# Altium schematic sheet Gateway_GNSS_Board.SchDoc
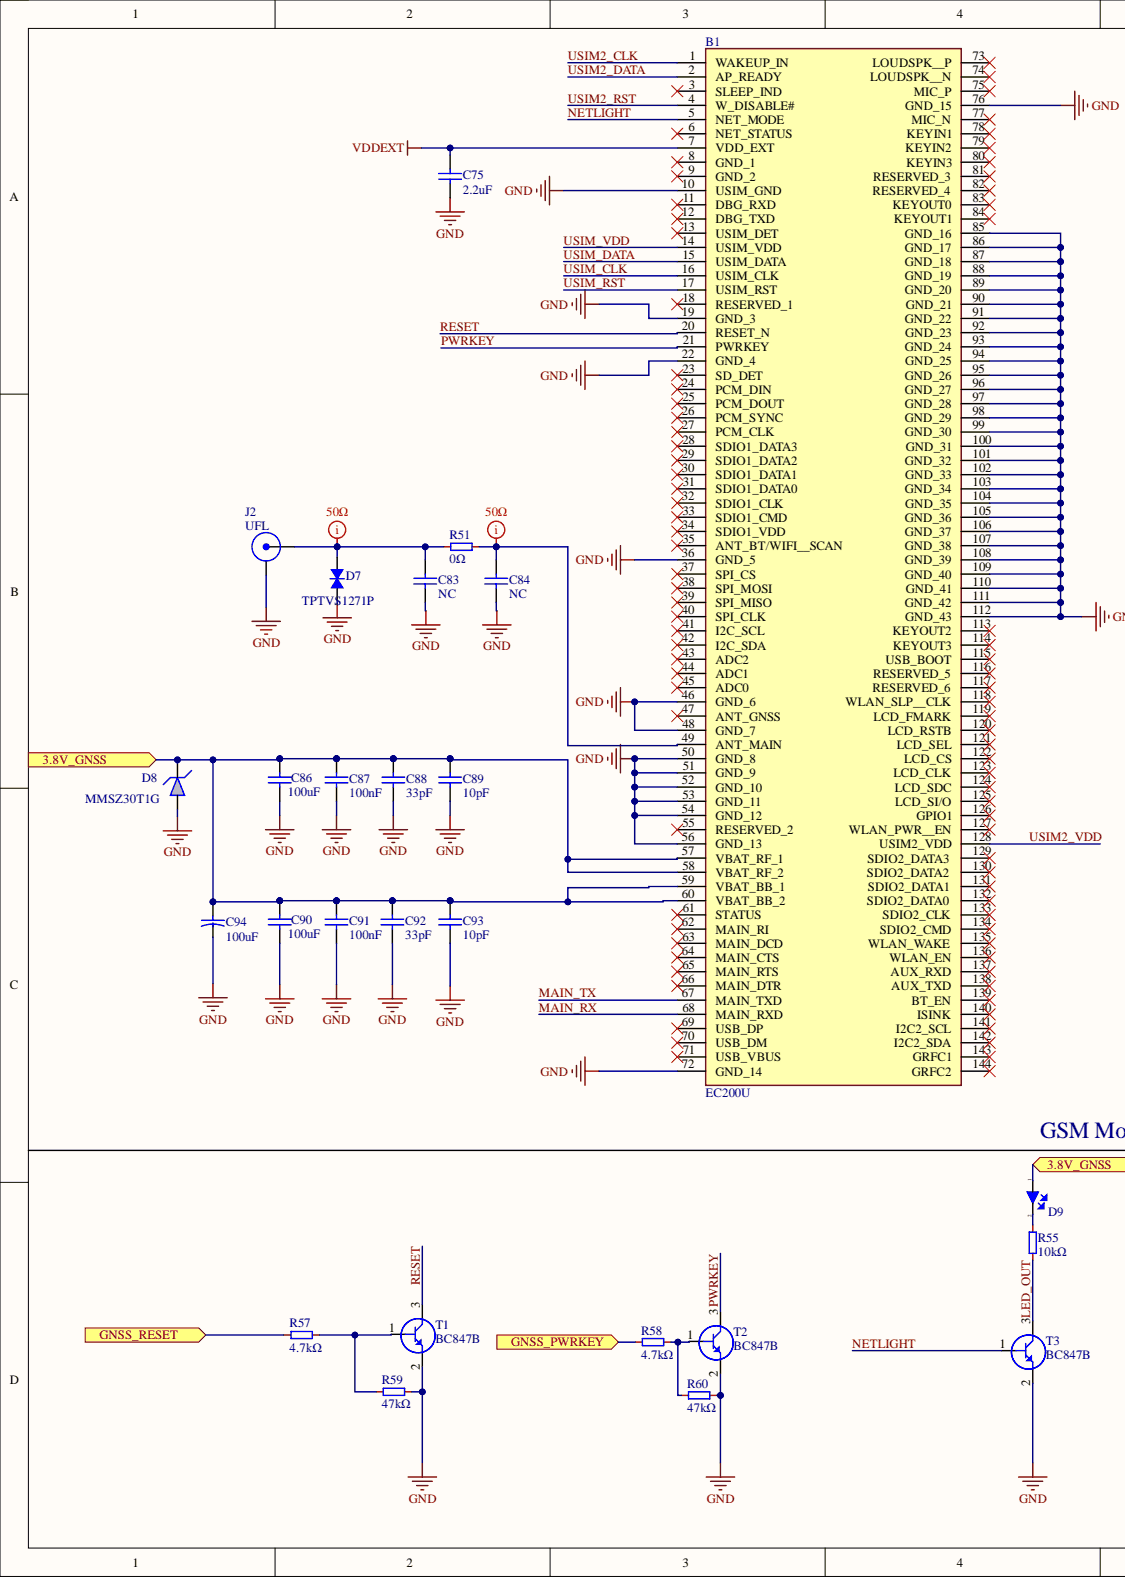
[diagram p0: Gateway_GNSS_Board.SchDoc, left]
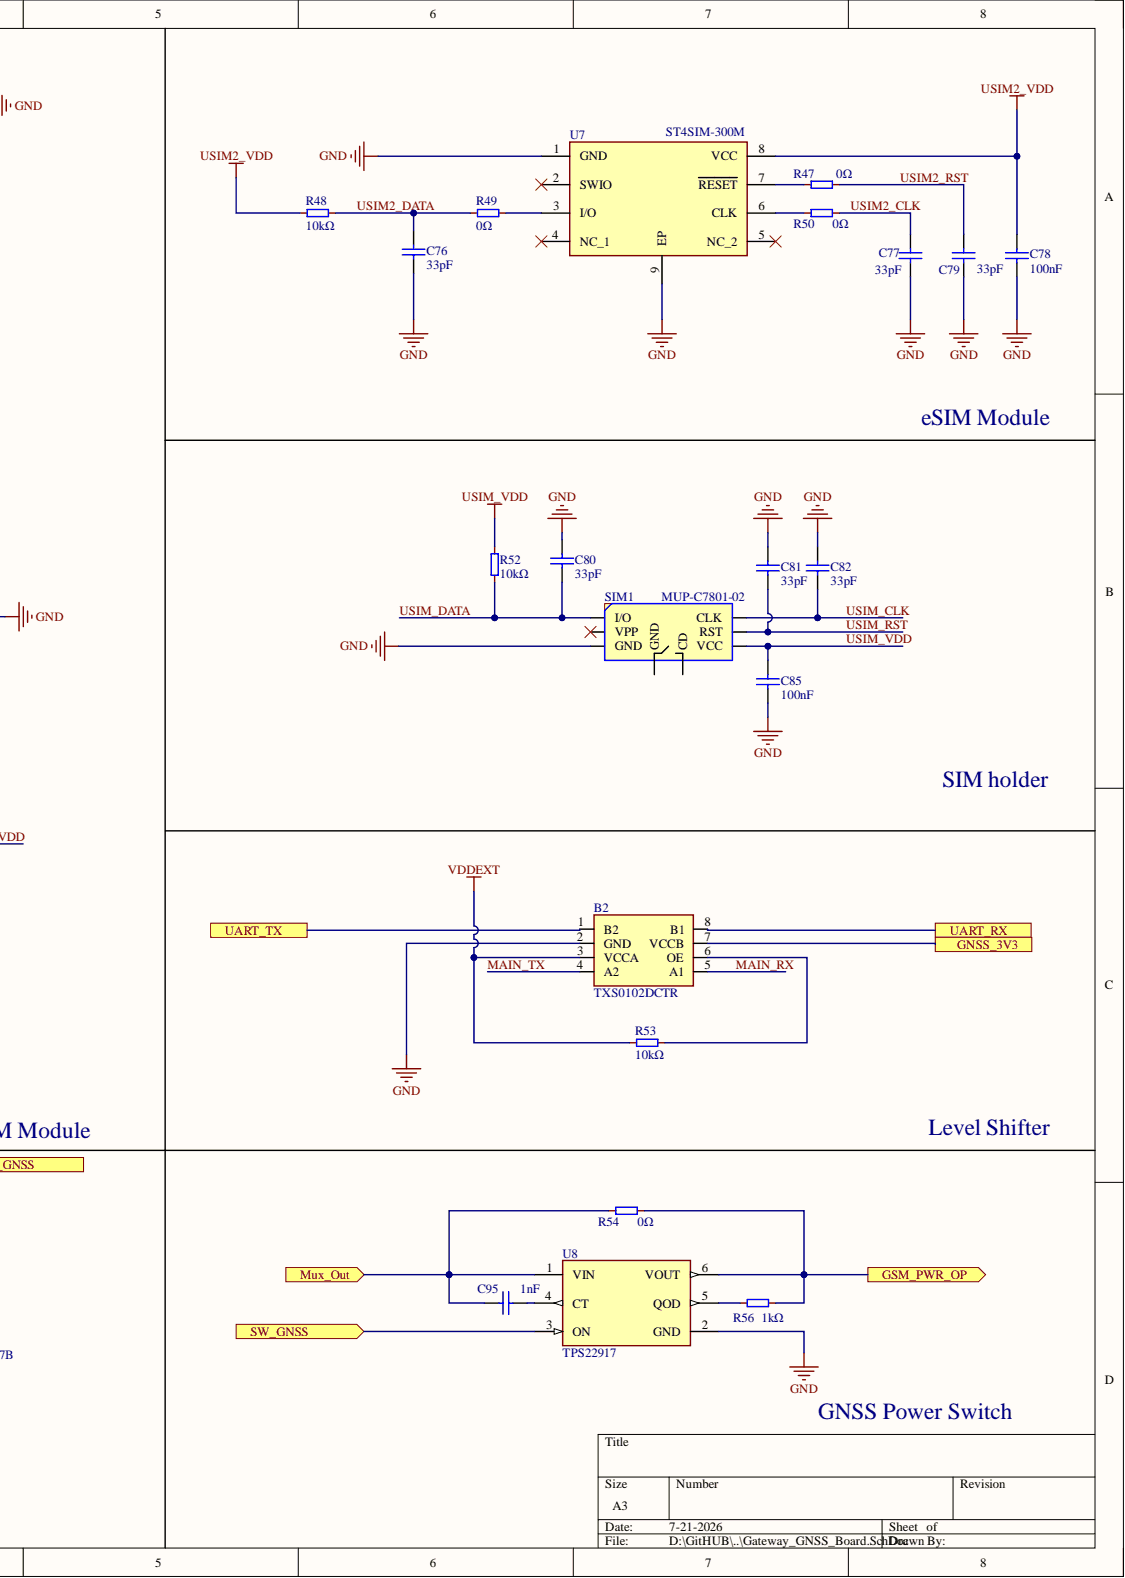
[diagram p1: Gateway_GNSS_Board.SchDoc, right]

## Parts
B1  EC200U  [EC200U]
B2  TXS0102DCTR  [SOP65P400X130-8N]
C75  2.2uF  [CAP0402]
C76  33pF  [CAP0402]
C77  33pF  [CAP0402]
C78  100nF  [CAP0402]
C79  33pF  [CAP0402]
C80  33pF  [CAP0402]
C81  33pF  [CAP0402]
C82  33pF  [CAP0402]
C83  NC  [CAP0402]
C84  NC  [CAP0402]
C85  100nF  [CAP0402]
C86  100uF  [CAP1206]
C87  100nF  [CAP0402]
C88  33pF  [CAP0402]
C89  10pF  [CAP0402]
C90  100uF  [CAP1206]
C91  100nF  [CAP0402]
C92  33pF  [CAP0402]
C93  10pF  [CAP0402]
C94  100uF  [8*10.2-CAP]
C95  1nF  [CAPC1005X06N]
D7  TPTVS1271P  [TVS Diode]
D8  MMSZ30T1G  [SOD 80]
D9  COLOR  [L_0805]  (value not drawn)
J2  UFL  [UFL]
R47  0Ω  [RESC0402]
R48  10kΩ  [RESC0402]
R49  0Ω  [RESC0402]
R50  0Ω  [RESC0402]
R51  0Ω  [RESC0402]
R52  10kΩ  [RESC0402]
R53  10kΩ  [RESC0402]
R54  0Ω  [RESC0402]
R55  10kΩ  [RESC0402]
R56  1kΩ  [RESC0402]
R57  4.7kΩ  [RESC0402]
R58  4.7kΩ  [RESC0402]
R59  47kΩ  [RESC0402]
R60  47kΩ  [RESC0402]
SIM1  MUP-C7801-02  [MUP-C7801-2]
T1  BC847B  [SOT23]
T2  BC847B  [SOT23]
T3  BC847B  [SOT23]
U7  ST4SIM-300M  [VFDFPN8 MFF2]
U8  TPS22917  [SOT23-6]

## Ports
3.8V_GNSS, GNSS_3V3, GNSS_PWRKEY, GNSS_RESET, GSM_PWR_OP, Mux_Out, SW_GNSS, UART_RX, UART_TX

## Nets
GND: B1.10(USIM_GND) B1.19(GND_3) B1.22(GND_4) B1.36(GND_5) B1.46(GND_6) B1.48(GND_7) B1.50(GND_8) B1.51(GND_9) B1.52(GND_10) B1.53(GND_11) B1.54(GND_12) B1.56(GND_13) B1.72(GND_14) B1.76(GND_15) B1.85(GND_16) B1.86(GND_17) B1.87(GND_18) B1.88(GND_19) B1.89(GND_20) B1.90(GND_21) B1.91(GND_22) B1.92(GND_23) B1.93(GND_24) B1.94(GND_25) B1.95(GND_26) B1.96(GND_27) B1.97(GND_28) B1.98(GND_29) B1.99(GND_30) B1.100(GND_31) B1.101(GND_32) B1.102(GND_33) B1.103(GND_34) B1.104(GND_35) B1.105(GND_36) B1.106(GND_37) B1.107(GND_38) B1.108(GND_39) B1.109(GND_40) B1.110(GND_41) B1.111(GND_42) B1.112(GND_43) B2.2(GND) C75.2 C76.2 C77.2 C78.2 C79.2 C80.1 C81.1 C82.1 C83.1 C84.1 C85.2 C86.1 C87.2 C88.2 C89.2 C90.1 C91.2 C92.2 C93.2 C94.2 D7.2 D8.2 J2.2 R59.1 R60.1 SIM1.GND T1.2 T2.2 T3.2 U7.1(GND) U7.9(EP) U8.2(GND)
VDDEXT: B1.7(VDD_EXT) B2.3(VCCA) C75.1 R53.2
3.8V_GNSS: B1.57(VBAT_RF_1) B1.58(VBAT_RF_2) B1.59(VBAT_BB_1) B1.60(VBAT_BB_2) C86.2 C87.1 C88.1 C89.1 C90.2 C91.1 C92.1 C93.1 C94.1 D8.1 D9.1 ; port 3.8V_GNSS
GNSS_3V3: B2.7(VCCB) ; port GNSS_3V3
GNSS_PWRKEY: R58.2 ; port GNSS_PWRKEY
GNSS_RESET: R57.2 ; port GNSS_RESET
GSM_PWR_OP: R54.2 R56.2 U8.6(VOUT) ; port GSM_PWR_OP
LED_OUT: R55.2 T3.3
MAIN_RX: B1.68(MAIN_RXD) B2.5(A1)
MAIN_TX: B1.67(MAIN_TXD) B2.4(A2)
Mux_Out: C95.2 R54.1 U8.1(VIN) ; port Mux_Out
NETLIGHT: B1.5(NET_MODE) T3.1
PWRKEY: B1.21(PWRKEY) T2.3
RESET: B1.20(RESET_N) T1.3
SW_GNSS: U8.3(ON) ; port SW_GNSS
UART_RX: B2.8(B1) ; port UART_RX
UART_TX: B2.1 ; port UART_TX
USIM2_CLK: B1.1(WAKEUP_IN) C77.1 R50.2
USIM2_DATA: B1.2(AP_READY) C76.1 R48.1 R49.1
USIM2_RST: B1.4(W_DISABLE#) C79.1 R47.2
USIM2_VDD: B1.128(USIM2_VDD) C78.1 R48.2 U7.8(VCC)
USIM_CLK: B1.16(USIM_CLK) C82.2 SIM1.CLK
USIM_DATA: B1.15(USIM_DATA) C80.2 R52.1 SIM1.I/O
USIM_RST: B1.17(USIM_RST) C81.2 SIM1.RST
USIM_VDD: B1.14(USIM_VDD) C85.1 R52.2 SIM1.VCC
NetB1_49: B1.49(ANT_MAIN) C84.2 R51.2
NetB2_6: B2.6(OE) R53.1
NetC83_2: C83.2 D7.1 J2.1 R51.1
NetC95_1: C95.1 U8.4(CT)
NetD9_2: D9.2 R55.1
NetR47_1: R47.1 U7.7(RESET)
NetR49_2: R49.2 U7.3(I/O)
NetR50_1: R50.1 U7.6(CLK)
NetR56_1: R56.1 U8.5(QOD)
NetR57_1: R57.1 R59.2 T1.1
NetR58_1: R58.1 R60.2 T2.1

Not connected: B1 3(SLEEP_IND) 6(NET_STATUS) 8(GND_1) 9(GND_2) 11(DBG_RXD) 12(DBG_TXD) 13(USIM_DET) 18(RESERVED_1) 23(SD_DET) 24(PCM_DIN) 25(PCM_DOUT) 26(PCM_SYNC) 27(PCM_CLK) 28(SDIO1_DATA3) 29(SDIO1_DATA2) 30(SDIO1_DATA1) 31(SDIO1_DATA0) 32(SDIO1_CLK) 33(SDIO1_CMD) 34(SDIO1_VDD) 35(ANT_BT/WIFI__SCAN) 37(SPI_CS) 38(SPI_MOSI) 39(SPI_MISO) 40(SPI_CLK) 41(I2C_SCL) 42(I2C_SDA) 43(ADC2) 44(ADC1) 45(ADC0) 47(ANT_GNSS) 55(RESERVED_2) 61(STATUS) 62(MAIN_RI) 63(MAIN_DCD) 64(MAIN_CTS) 65(MAIN_RTS) 66(MAIN_DTR) 69(USB_DP) 70(USB_DM) 71(USB_VBUS) 73(LOUDSPK__P) 74(LOUDSPK__N) 75(MIC_P) 77(MIC_N) 78(KEYIN1) 79(KEYIN2) 80(KEYIN3) 81(RESERVED_3) 82(RESERVED_4) 83(KEYOUT0) 84(KEYOUT1) 113(KEYOUT2) 114(KEYOUT3) 115(USB_BOOT) 116(RESERVED_5) 117(RESERVED_6) 118(WLAN_SLP__CLK) 119(LCD_FMARK) 120(LCD_RSTB) 121(LCD_SEL) 122(LCD_CS) 123(LCD_CLK) 124(LCD_SDC) 125(LCD_SI/O) 126(GPIO1) 127(WLAN_PWR__EN) 129(SDIO2_DATA3) 130(SDIO2_DATA2) 131(SDIO2_DATA1) 132(SDIO2_DATA0) 133(SDIO2_CLK) 134(SDIO2_CMD) 135(WLAN_WAKE) 136(WLAN_EN) 137(AUX_RXD) 138(AUX_TXD) 139(BT_EN) 140(ISINK) 141(I2C2_SCL) 142(I2C2_SDA) 143(GRFC1) 144(GRFC2); SIM1 CD VPP; U7 2(SWIO) 4(NC_1) 5(NC_2)

## Library data of the parts
B1 = EC200U: LTE standard module Bluetooth wireless communication 4G cat1 module all-network; Manufacturer_Name: Quectel; Geometry.Height: 2.6mm; Component Kind: Standard; Datasheet Link: https://drive.google.com/file/d/1eptLu98ynaKmYC8Qn_q-jmLHFTbR9duW/view?usp=sharing; Manufacturer_Part_Number: EC200U; Library Name: macnman_3.0.SchLib
B2 = TXS0102DCTR: Translation - Voltage Levels 2B Bidir Vltg-Level Translator; Manufacturer: Texas Instruments; Geometry.Height: 1.3mm; Component Kind: Standard; Datasheet Link: https://www.ti.com/lit/ds/symlink/txs0102.pdf?ts=1619522054575&ref_url=https%253A%252F%252Fwww.ti.com%252Fstore%252Fti%252Fen%252Fp%252Fproduct%252F%253Fp%253DTXS0102DCTT; Manufacturer_Part_Number: TXS0102DCTR; Mouser Part Number: TXS0102DCTR; Mouser Price/Stock: https://www.mouser.co.uk/ProductDetail/Texas-Instruments/TXS0102DCTTE4?qs=IUbkBnfnSQLBbpjVS5PRXQ%3D%3D; Library Name: macnman_3.0.SchLib
C75 = Capa_0402: Ceramic Capacitor - SMD X7R 0402 2.2uF 10V 10%; Manufacturer: Murata; Manufacturer_Part_Number: GRM155Z71A225KE44D; Component Kind: Standard; Supplier 1: Robu; Supplier Part Number 1: GRM155Z71A225KE44D; Library Name: macnman_2.0.SchLib
C76 C77 C79-C82 C88 C92 = Capa_0402: 33pF 50V Capacitor 5% Tolerance CC0402JRNPO9BN330- 0402 Package; Manufacturer: YAGEO; Component Kind: Standard; Manufacturer_Part_Number: CC0402JRNPO9BN330; Library Name: macnman_2.0.SchLib
C78 C85 C87 C91 = Capa_0402: Ceramic Capacitor C0G, NP0 0402 100nF 35V; Manufacturer: MURATA; Manufacturer_Part_Number: GRM155R61A104KA01J; Component Kind: Standard; Library Name: macnman_2.0.SchLib
C83 C84 = Capa_0402: Ceramic Capacitor C0G, NC NP0 0402; Manufacturer: YAGEO; Manufacturer_Part_Number: CC0402KRX7R9BB105; Component Kind: Net Tie; Library Name: macnman_2.0.SchLib
C86 C90 = CAP_0805: Ceramic Capacitor - SMD 100uF 10V 20% 1206 X5R; Manufacturer: MURATA; Manufacturer_Part_Number: GRM31CR61A107MEA8K; Component Kind: Standard; Supplier: Robu; Supplier_Part_Number: GRM31CR61A107MEA8K; Library Name: macnman_2.0.SchLib
C89 C93 = Capa_0402: Ceramic Capacitor C0G, NP0 0402 10pF 35V; Manufacturer: YAGEO; Manufacturer_Part_Number: CC0402JRNPO9BN100; Component Kind: Standard; Library Name: macnman_2.0.SchLib
C94 = CAP_EL: Aluminum Electrolytic - Radial 100µF 10V 20%; Manufacturer: Lelon; Manufacturer_Part_Number: VES101M1ATR; Component Kind: Standard; Supplier: Robu; Supplier Part Number 1: VES101M1ATR; Library Name: macnman_2.0.SchLib
C95 = MCD-00198: Ceramic Capacitor - SMD 1nF 25V C0G 5% 0402; Manufacturer: Murata; Manufacturer_Part_Number: GRT1555C1E102JA02J; Component Kind: Standard; Supplier 1: DigiKey; Supplier 2: Mouser; Supplier Part Number 1: 490-GRT1555C1E102JA02JCT-ND; Supplier Part Number 2: 81-GRT1555C1E102JA2J; Value: 1nF; Library Name: macnman_3.0.SchLib
D7 = TVS Diode: 0.35pF 20kV Bidirectional Discrete TVS; Manufacturer: Tech Public; Component Kind: Standard; Manufacturer_Part_Number: TPTVS1271P; Library Name: macnman_3.0.SchLib
D8 = ZENER_DIODE: Zener Diode  3V3 SOD-80 1/5WATT; Manufacturer: Onsemi; Suplier: Robu; Component Kind: Standard; Manufacturer_Part_Number: MMSZ30T1G; Package: SOD-80; Parameter 1: MMSZ30T1G; Library Name: macnman_2.0.SchLib
D9 = L0805: LED 0805 SMD; Manufacturer: Everlight; Manufacturer_Part_Number: 17-21SURC/S530-A3/4T; Component Kind: Standard; Supplier 1: Evalta; Supplier 2: Sharvi; Supplier Part Number 1: 17-21SURC/S530-A3/4T; Supplier Part Number 2: ST2103SD2639; Library Name: macnman_3.0.SchLib
J2 = SMA_T_9.52_NF: SMA Jack, UFL Edge Mount, 50 Ohms; Manufacturer: SAMTEC; Manufacturer_Part_Number: SMA-J-P-H-ST-EM1; Component Kind: Standard; Library Name: macnman_3.0.SchLib
R47 R49-R51 R54 = RES_0402: Thick Film Resistors - SMD 0Ohm 0402 1/16W; Manufacturer: YAGEO; Manufacturer_Part_Number: RC0402FR-070RL; Component Kind: Standard; ComponentLink1Description: New link; Supplier: Robu; Supplier_Part_Number: RC0402FR-070RL; Library Name: macnman_2.0.SchLib
R48 R52 R53 R55 = Resister: Thick Flim Resistor - 10k 0402 100ppm 1% 1/10W; Manufacturer: Pansonic; Manufacturer_Part_Number: ERJ2RKF1002X; Component Kind: Standard; Package: 0402; Supplier 1: Robu; Supplier Part Number 1: P10.0KLCT-ND; Library Name: macnman_3.0.SchLib
R56 = RES_0402: Thin Film Resistors - SMD 1K 0.1% 50PPM 1/1 6W 0402 50V; Manufacturer: YAGEO; Manufacturer_Part_Number: RT0402BRE071KL; Component Kind: Standard; ComponentLink1Description: New link; Supplier: Robu; Supplier Part Number: RT0402BRE071KL; Library Name: macnman_2.0.SchLib
R57 R58 = RES_0402: Thin Film Resistors - SMD 4.7K 1% 100PPM 1/10W 0402 100mW; Manufacturer: Panasonic; Manufacturer_Part_Number: ERJ2RKF4701X; Component Kind: Standard; ComponentLink1Description: New link; Supplier: Robu; Supplier_Part_Number: ERJ2RKF4701X; Library Name: macnman_2.0.SchLib
R59 R60 = RES_0402: Thick Film Resistors - SMD 47K 1% 100PPM 1/16W 0402 100mW; Manufacturer: Panasonic; Manufacturer_Part_Number: RC0402FR-0747KL; Component Kind: Standard; ComponentLink1Description: New link; Supplier: Robu; Supplier_Part_Number: RC0402FR-0747KLv; Library Name: macnman_2.0.SchLib
SIM1 = MUP-C7801-02: MUP-C7801-02 – Push-Push Nano Sim Card Connector Without Post Normally Closed – 7Pin SMD Package; Manufacturer: MUP Industrial; Component Kind: Standard; Manufacturer_Part_Number: MUP-C7801-02; Library Name: macnman_3.0.SchLib
T1-T3 = BC847: BC847B – NPN General Purpose Transistor – SOT-23 Package; Manufacturer: Nexperia; Component Kind: Standard; Manufacturer_Part_Number: BC847B; Package: SOT23; Library Name: macnman_3.0.SchLib
U7 = ST4SIM-300M: ST4SIM-300M GSM eSIM for IoT & TCA; Manufacturer: ST Microelectronics; Manufacturer_Part_Number: ST4SI3M004600HFW; Component Kind: Standard; Supplier 1: Mouser; Supplier Part Number 1: ST4SI3M004600HFW; Library Name: macnman_3.0.SchLib
U8 = TPS22917: Power Switch ICs - Power Distribution 5.5-V, 2-A, 80-m , 10-nA leakage load switch adj. rise time and adj output discharge 6-SOT-23; Manufacturer: Texas Instruments; Component Kind: Standard; Manufacturer_Part_Number: TPS22917; Package: SOT23-6; Library Name: macnman_2.0.SchLib
